# Altium schematic sheet Sound.SchDoc
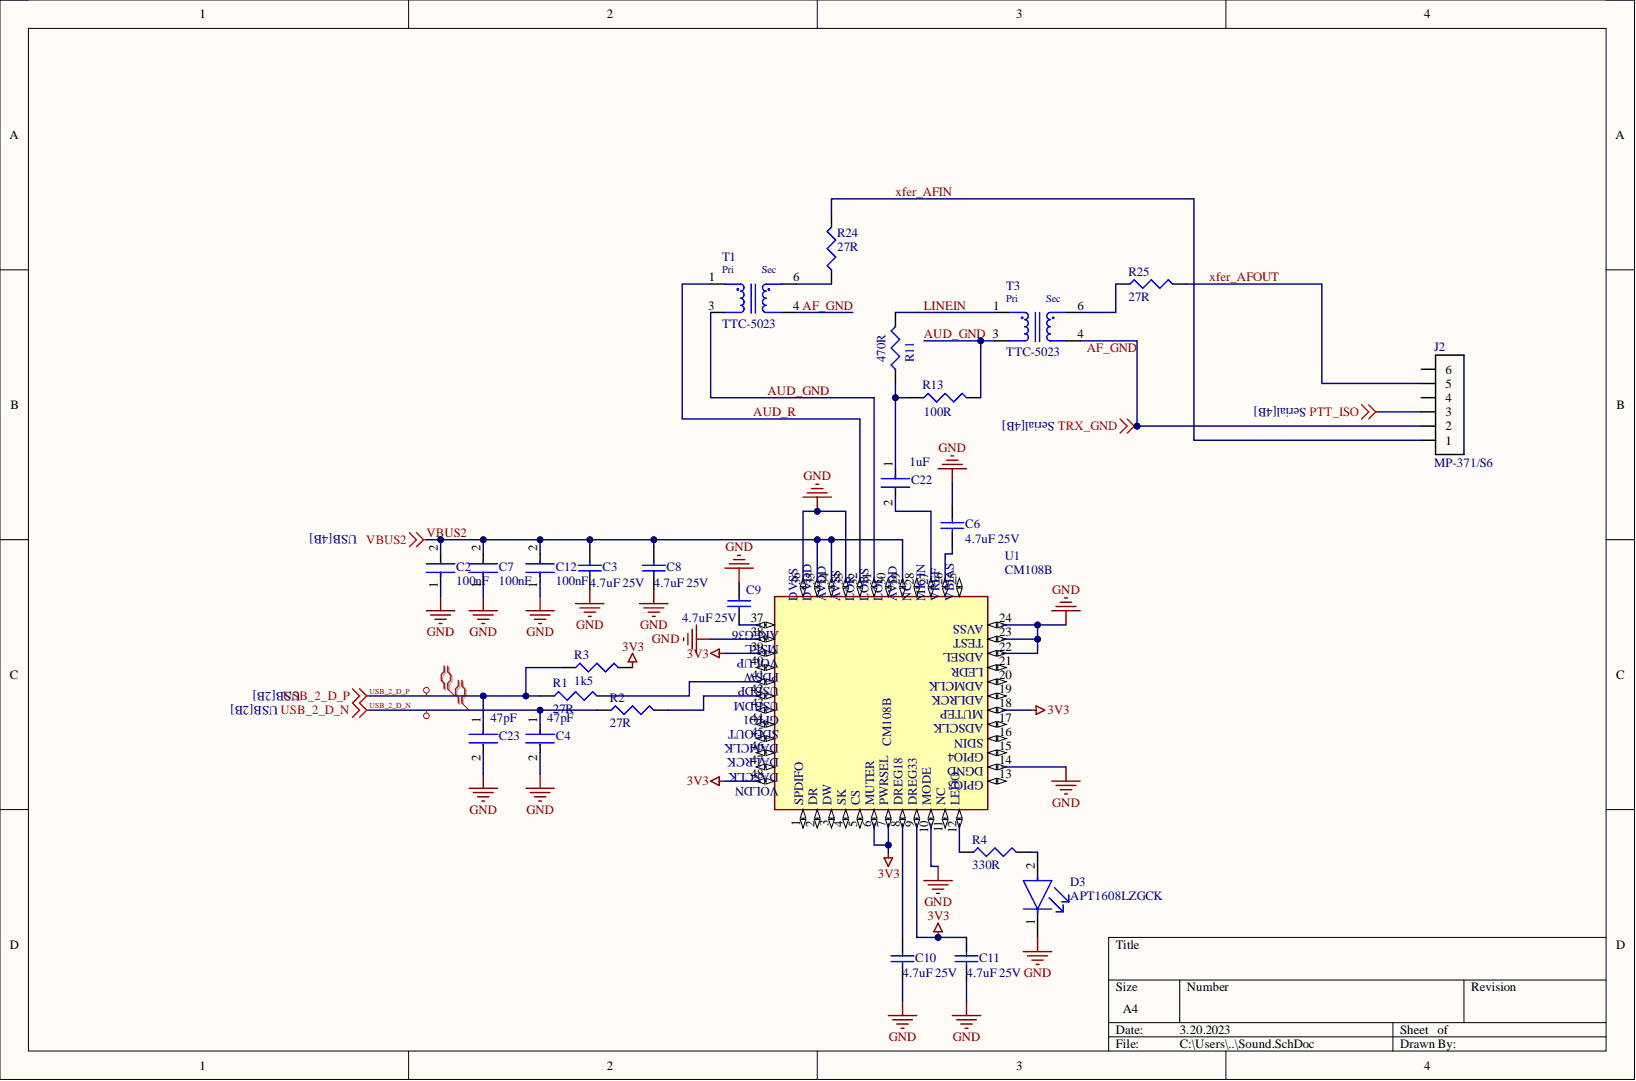
[diagram p0: Sound.SchDoc]

## Parts
C2  Capacitance: 100nF  [FP-CL10-IPC_B]  (hidden comment: CL10B104KC8NNNC)
C3  4.7uF 25V  value 4.7uF  [CAPC1210(3225)220_M]
C4  Capacitance: 47pF  [FP-CL10-IPC_C]  (hidden comment: CL10C470JC8NNNC)
C6  4.7uF 25V  value 4.7uF  [CAPC1210(3225)220_M]
C7  Capacitance: 100nF  [FP-CL10-IPC_B]  (hidden comment: CL10B104KC8NNNC)
C8  4.7uF 25V  value 4.7uF  [CAPC1210(3225)220_M]
C9  4.7uF 25V  value 4.7uF  [CAPC1210(3225)220_M]
C10  4.7uF 25V  value 4.7uF  [CAPC1210(3225)220_M]
C11  4.7uF 25V  value 4.7uF  [CAPC1210(3225)220_M]
C12  Capacitance: 100nF  [FP-CL10-IPC_B]  (hidden comment: CL10B104KC8NNNC)
C22  Capacitance: 1uF  [FP-CC0603-DB-MFG]  (hidden comment: CC0603KRX7R9BB105)
C23  Capacitance: 47pF  [FP-CL10-IPC_C]  (hidden comment: CL10C470JC8NNNC)
D3  APT1608LZGCK  [FP-APT1608LZGCK-MFG]
J2  MP-371/S6  [MiniDIN6_TopSolder_Lumberg]
R1  27R  [J1-0603]
R2  27R  [J1-0603]
R3  1k5  [J1-0603]
R4  330R  [J1-0603]
R11  470R  [J1-0603]
R13  100R  [J1-0603]
R24  27R  [J1-0603]
R25  27R  [J1-0603]
T1  TTC-5023  [TRANSFORMER_TTC-5023]
T3  TTC-5023  [TRANSFORMER_TTC-5023]
U1  CM108B  [TQFP48]

## Nets
3V3: C11.2 R3.2 U1.6(MUTER) U1.7(PWRSEL) U1.9(DREG33) U1.18(MUTEP) U1.39 U1.48
GND: C2.1 C3.1 C4.2 C6.1 C7.1 C8.1 C9.2 C10.1 C11.1 C12.1 C23.2 D3.1 U1.10(MODE) U1.14(DGND) U1.22(ADSEL) U1.23(TEST) U1.24(AVSS) U1.33 U1.36 U1.38
PTT_ISO: J2.3
AF_GND: J2.2 T1.4 T3.4
AUD_GND: R13.2 T1.3 T3.3 U1.31
AUD_R: T1.1 U1.32
LINEIN: R11.2 T3.1
USB_2_D_N: C4.1 R2.1
USB_2_D_P: C23.1 R1.1 R3.1
VBUS2: C2.2 C3.2 C7.2 C8.2 C12.2 U1.29 U1.34 U1.35
xfer_AFIN: J2.1 R24.2
xfer_AFOUT: J2.5 R25.2
NetC6_2: C6.2 U1.26
NetC9_1: C9.1 U1.37
NetC10_2: C10.2 U1.8(DREG18)
NetC22_1: C22.1 R11.1 R13.1
NetC22_2: C22.2 U1.27
NetD3_2: D3.2 R4.2
NetR1_2: R1.2 U1.41
NetR2_2: R2.2 U1.42
NetR4_1: R4.1 U1.12(LEDO)
NetR24_1: R24.1 T1.6
NetR25_1: R25.1 T3.6

Not connected: J2 4 6; U1 1(SPDIFO) 2(DR) 3(DW) 4(SK) 5(CS) 11(NC) 13(GPIO3) 15(GPIO4) 16(SDIN) 17(ADSCLK) 19(ADLRCK) 20(ADMCLK) 21(LEDR) 25 28 30 40 43 44 45 46 47

## Library data of the parts
C2 C7 C12 = CMP-13271-004036-1: Cap Ceramic 100nF 100V X7R ±10% Pad SMD 0603 +125°C T/R; Case Code (Imperial): 0603; Case Code (Metric): 1608; Case/Package: 0603; Component Kind: Standard; JLCPCBPartNum: C15725; Length: 0.063inch; Library Name: Altium Content Vault; Max Operating Temperature: 125°C; Min Operating Temperature: -55°C; Mount: Surface Mount; Packaging: Cut Tape; Thickness: 0.035inch; Tolerance: 10%; Voltage Rating: 100V; Width: 0.031inch
C3 C6 C8-C11 = CMP-1038-02151-2: CAP 4.7uF 25V ±10% 1210 (3225 Metric) Thickness 2.2mm SMD; Case-EIA: 1210; Case-Metric: 3225; Tolerance: Â±10%; Component Kind: Standard; JLCPCBPartNum: C86052; Library Name: Altium Content Vault; Max Thickness: 2.2 mm; Rated Voltage: 25 V; Technology: SMT
C4 C23 = CMP-13271-001416-1: Cap Ceramic 47pF 100V C0G ±5% Pad SMD 0603 +125°C T/R; Case Code (Imperial): 0603; Case Code (Metric): 1608; Case/Package: 0603; Component Kind: Standard; Depth: 0.8mm; Dielectric: C0G; Height: 0.8mm; JLCPCBPartNum: C1671; Length: 1.6mm; Library Name: Altium Content Vault; Material: Ceramic; Max Operating Temperature: 125°C; Min Operating Temperature: -55°C; Mount: Surface Mount; Packaging: Tape and Reel; Radiation Hardening: No; Thickness: 0.035inch; Tolerance: 5%; Voltage Rating: 100V; Voltage Rating (DC): 100V; Width: 0.031inch
C22 = CMP-03422-000761-2: CAP CER 1UF 50V X7R 0603; Tolerance: 10%; Case Code (Imperial): 0603; Case Code (Metric): 1608; Case/Package: 0603; Component Kind: Standard; Dielectric: X7R; Halogen Free: Halogen Free; JLCPCBPartNum: C559769; Lead Free: Lead Free; Length: 0.063inch; Library Name: Altium Content Vault; Max Operating Temperature: 125°C; Min Operating Temperature: -55°C; Mount: Surface Mount; Package Quantity: 4000; Packaging: Tape and Reel; Resistor Type: Ceramic; Termination: SMD/SMT; Thickness: 0.035inch; Voltage Rating: 50V; Voltage Rating (DC): 50V; Weight: 7.1E-05oz; Width: 0.031inch
D3 = CMP-44529-000040-1: LED GREEN CLEAR 2SMD; Dominant Wavelength: 525nm; Forward Voltage: 2.65V; Lens Color: Colorless; Luminous Intensity: 50mcd; Test Current: 2mA; Viewing Angle: 120°; Case Code (Imperial): 0603; Case Code (Metric): 1608; Case/Package: 0603; Color: Green; Component Kind: Standard; Height: 0.75mm; JLCPCBPartNum: C1322302; Length: 1.6mm; Lens Transparency: Clear; Library Name: Altium Content Vault; Mount: Surface Mount; Packaging: Cut Tape; Width: 0.8mm
J2 = MiniDin6_SMT: Miniature circular connectors MP-371/S6; Component Kind: Standard; Digikey_PartNum: 2057-MDP-006-ND; Library Name: MiniDin.IntLib
R1-R4 R11 R13 R24 R25 = Res3: Resistor; Component Kind: Standard; LatestRevisionDate: 29-May-2009; LatestRevisionNote: IPC-7351 Footprint Added.; Library Name: Miscellaneous Devices.IntLib; PackageDescription: Chip Resistor; PackageReference: J1-0603; Published: 8-Jun-2000; Signal Integrity: Res; Simulation: RESISTOR; Publisher: Altium Limited
  R1 R2 R24 R25: JLCPCBPartNum: C192531; Value: 27R
  R3: JLCPCBPartNum: C192164; Value: 1k5
  R4: JLCPCBPartNum: C23138; Value: 330R
  R11: JLCPCBPartNum: C25804; Value: 470R
  R13: JLCPCBPartNum: C22775; Value: 100R
T1 T3 = CMP-012-00000-1: 600 Impedance Primary Ohms 600 Impedance Secondary Ohms 1:1 Audio Transformer 200Hz ~ 4kHz Surface Mount; MF: Tamura; Availability: Unavailable; Case/Package: Tamura; Component Kind: Standard; Component Type: Standard; Design Item ID: TTC-5023; JLCPCBPartNum: C840532; MP: TTC-5023; Pins: 4; Price: None; SnapEDA_Link: https://www.snapeda.com/parts/TTC-5023/Tamura/view-part/2878352/?ref=snap; Source: SnapEDAsch.SchLib; Library Name: Torqeedo Workspace
U1 = CMP-002-000000-1: USB Audio Codec improved on CM; MF: Cmedia; Availability: Unavailable; Check_prices: https://www.snapeda.com/parts/CM108B/Cmedia/view-part/424266/?ref=eda; Component Kind: Standard; Component Type: Interface; Design Item ID: CM108B; JLCPCBPartNum: C371347; Library Name: YaSIf.SCHLIB; MP: CM108B; Package: None; Pin Count: 48; Price: None; SnapEDA_Link: https://www.snapeda.com/parts/CM108B/Cmedia/view-part/424266/?ref=snap; Source: CM108B.SchLib
